# Altium schematic sheet IPM_Module.SchDoc
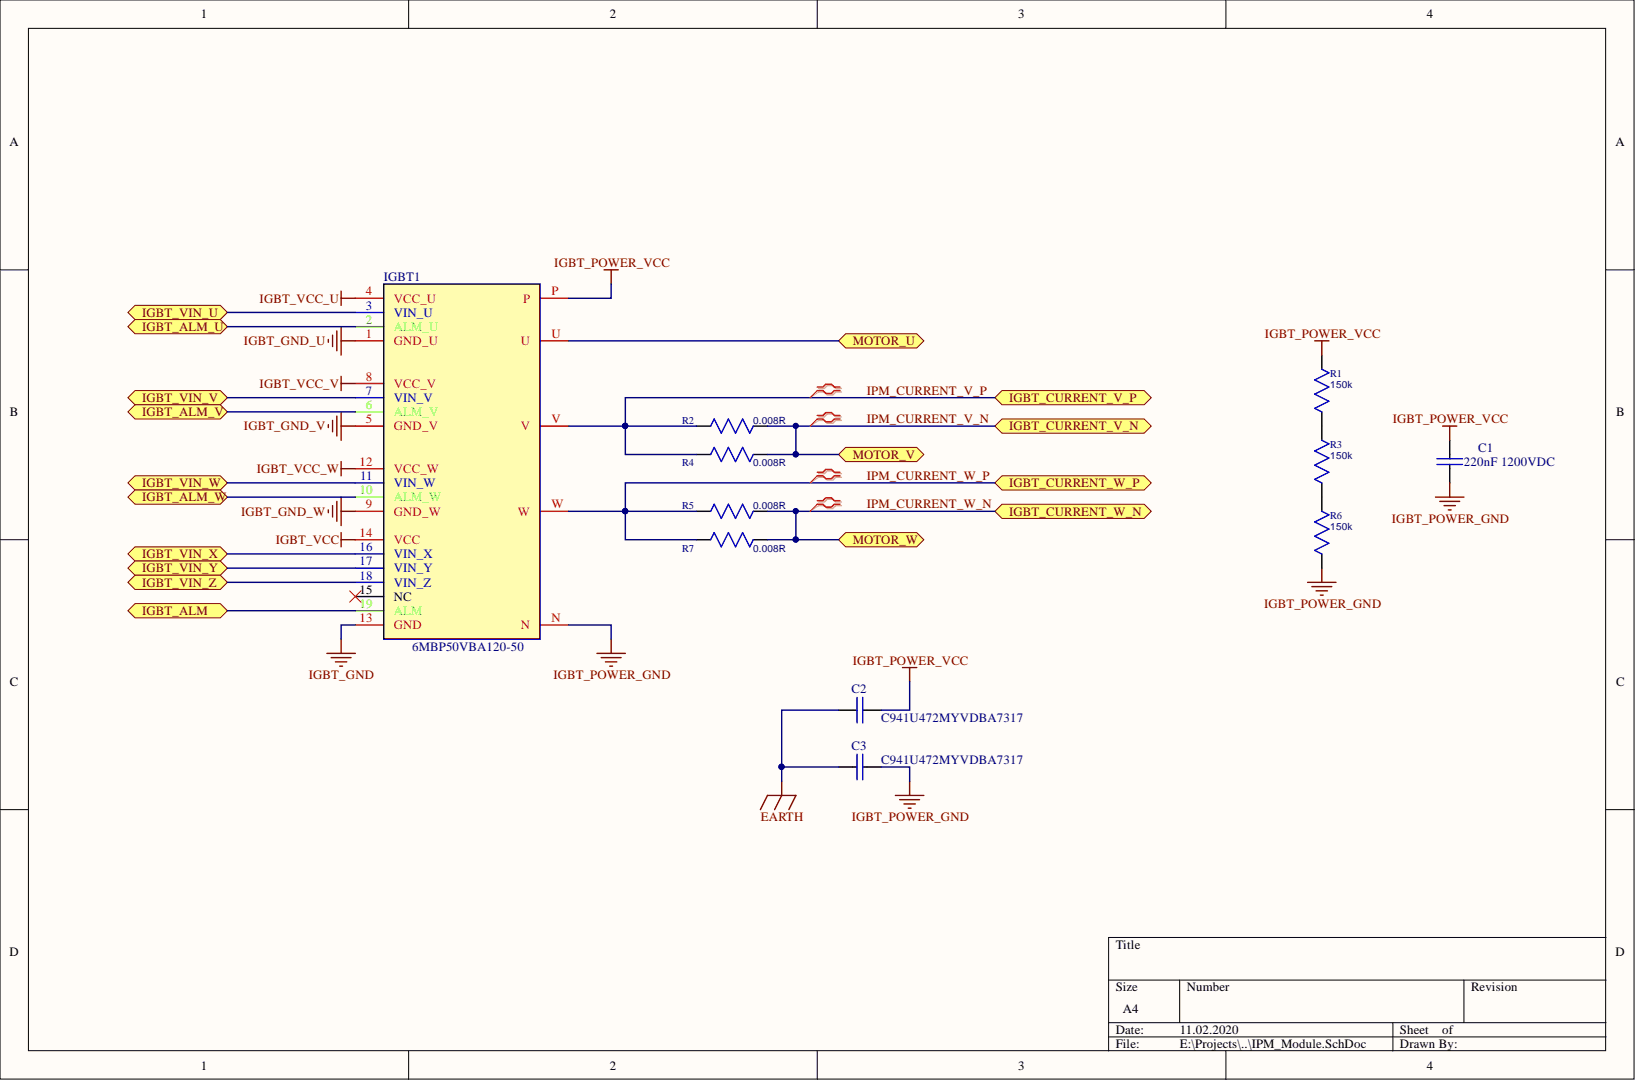
[diagram p0: IPM_Module.SchDoc]

## Parts
C1  220nF 1200VDC  [224PPA122K]
C2  C941U472MYVDBA7317  [X1/Y2 C900]
C3  C941U472MYVDBA7317  [X1/Y2 C900]
IGBT1  6MBP50VBA120-50  [P626]
R1  150k  [R_1210]
R2  0.008R  [R_2512]
R3  150k  [R_1210]
R4  0.008R  [R_2512]
R5  0.008R  [R_2512]
R6  150k  [R_1210]
R7  0.008R  [R_2512]

## Ports
IGBT_ALM, IGBT_ALM_U, IGBT_ALM_V, IGBT_ALM_W, IGBT_CURRENT_V_N, IGBT_CURRENT_V_P, IGBT_CURRENT_W_N, IGBT_CURRENT_W_P, IGBT_VIN_U, IGBT_VIN_V, IGBT_VIN_W, IGBT_VIN_X, IGBT_VIN_Y, IGBT_VIN_Z, MOTOR_U, MOTOR_V, MOTOR_W

## Nets
EARTH: C2.2 C3.2
IGBT_GND: IGBT1.13(GND)
IGBT_GND_U: IGBT1.1(GND_U)
IGBT_GND_V: IGBT1.5(GND_V)
IGBT_GND_W: IGBT1.9(GND_W)
IGBT_POWER_GND: C1.2 C3.1 IGBT1.N R6.2
IGBT_POWER_VCC: C1.1 C2.1 IGBT1.P R1.1
IGBT_VCC: IGBT1.14(VCC)
IGBT_VCC_U: IGBT1.4(VCC_U)
IGBT_VCC_V: IGBT1.8(VCC_V)
IGBT_VCC_W: IGBT1.12(VCC_W)
IGBT_ALM: IGBT1.19(ALM) ; port IGBT_ALM
IGBT_ALM_U: IGBT1.2(ALM_U) ; port IGBT_ALM_U
IGBT_ALM_V: IGBT1.6(ALM_V) ; port IGBT_ALM_V
IGBT_ALM_W: IGBT1.10(ALM_W) ; port IGBT_ALM_W
IGBT_VIN_U: IGBT1.3(VIN_U) ; port IGBT_VIN_U
IGBT_VIN_V: IGBT1.7(VIN_V) ; port IGBT_VIN_V
IGBT_VIN_W: IGBT1.11(VIN_W) ; port IGBT_VIN_W
IGBT_VIN_X: IGBT1.16(VIN_X) ; port IGBT_VIN_X
IGBT_VIN_Y: IGBT1.17(VIN_Y) ; port IGBT_VIN_Y
IGBT_VIN_Z: IGBT1.18(VIN_Z) ; port IGBT_VIN_Z
IPM_CURRENT_V_N: R2.1 R4.1 ; port IGBT_CURRENT_V_N, port MOTOR_V
IPM_CURRENT_V_P: IGBT1.V R2.2 R4.2 ; port IGBT_CURRENT_V_P
IPM_CURRENT_W_N: R5.1 R7.1 ; port IGBT_CURRENT_W_N, port MOTOR_W
IPM_CURRENT_W_P: IGBT1.W R5.2 R7.2 ; port IGBT_CURRENT_W_P
MOTOR_U: IGBT1.U ; port MOTOR_U
NetR1_2: R1.2 R3.1
NetR3_2: R3.2 R6.1

Not connected: IGBT1 15(NC)

## Library data of the parts
C1 = 1200VDC_D19.5L34: Polypropilene Film Capacitor; Component Kind: Standard; Library Name: Capacitors.SchLib; Value: 220nF 1200VDC
C2 C3 = X1/Y2 C900 capacitor: Radial Leaded Ceramic Disc Capacitors Y2/X1; Component Kind: Standard; Library Name: Capacitors.SchLib; Value: C941U472MYVDBA7317
IGBT1 = 6MBP50VBA120-50: IGBT Module V Series 1200V 50A IPM; Component Kind: Standard; Library Name: ModuleIGBT.SchLib; Value: 6MBP50VBA120-50
R1 R3 R6 = R1210: Resistor; Component Kind: Standard; Library Name: Resistors.SchLib; Value: 150k
R2 R4 R5 R7 = R2512: Resistor; Component Kind: Standard; Library Name: Resistors.SchLib; Value: 0.008R
